# Altium schematic sheet Controller.SchDoc
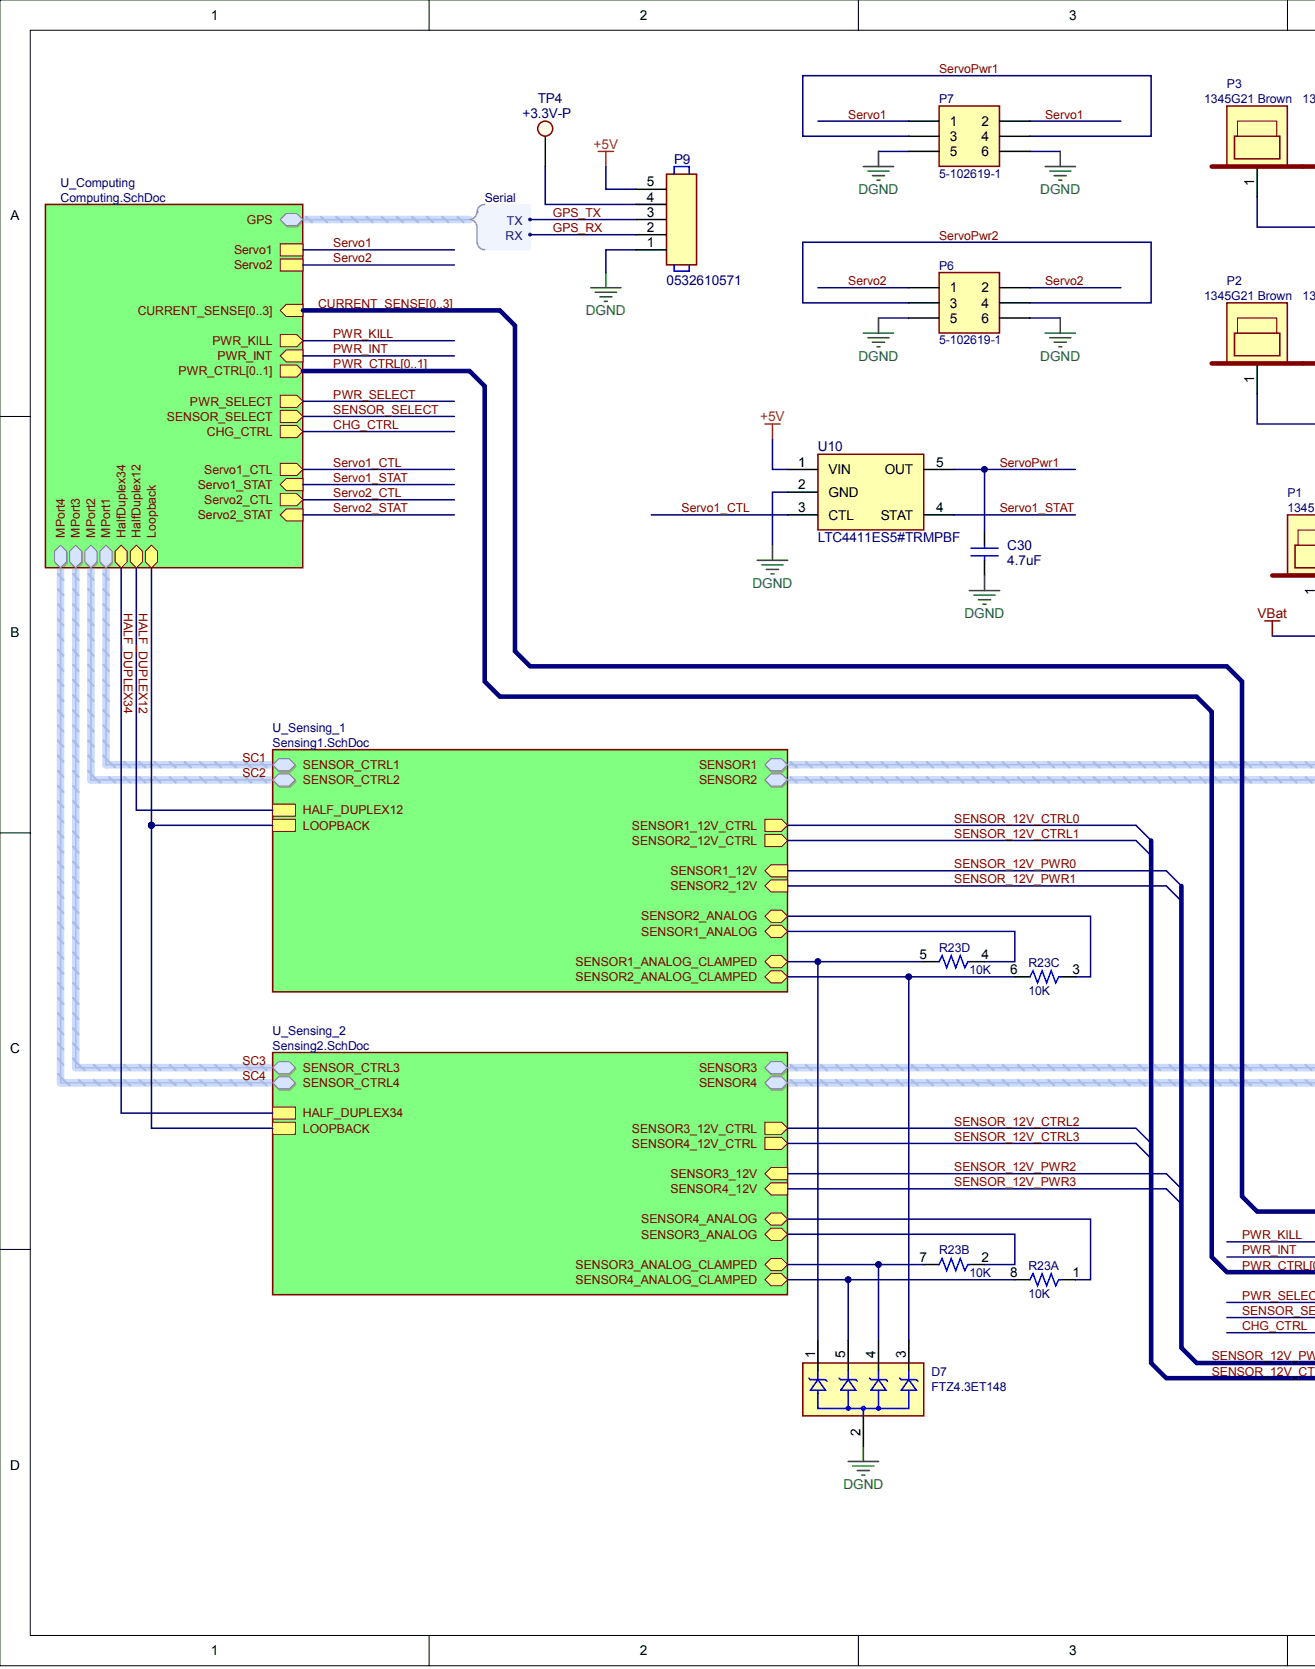
[diagram p0: Controller.SchDoc, left]
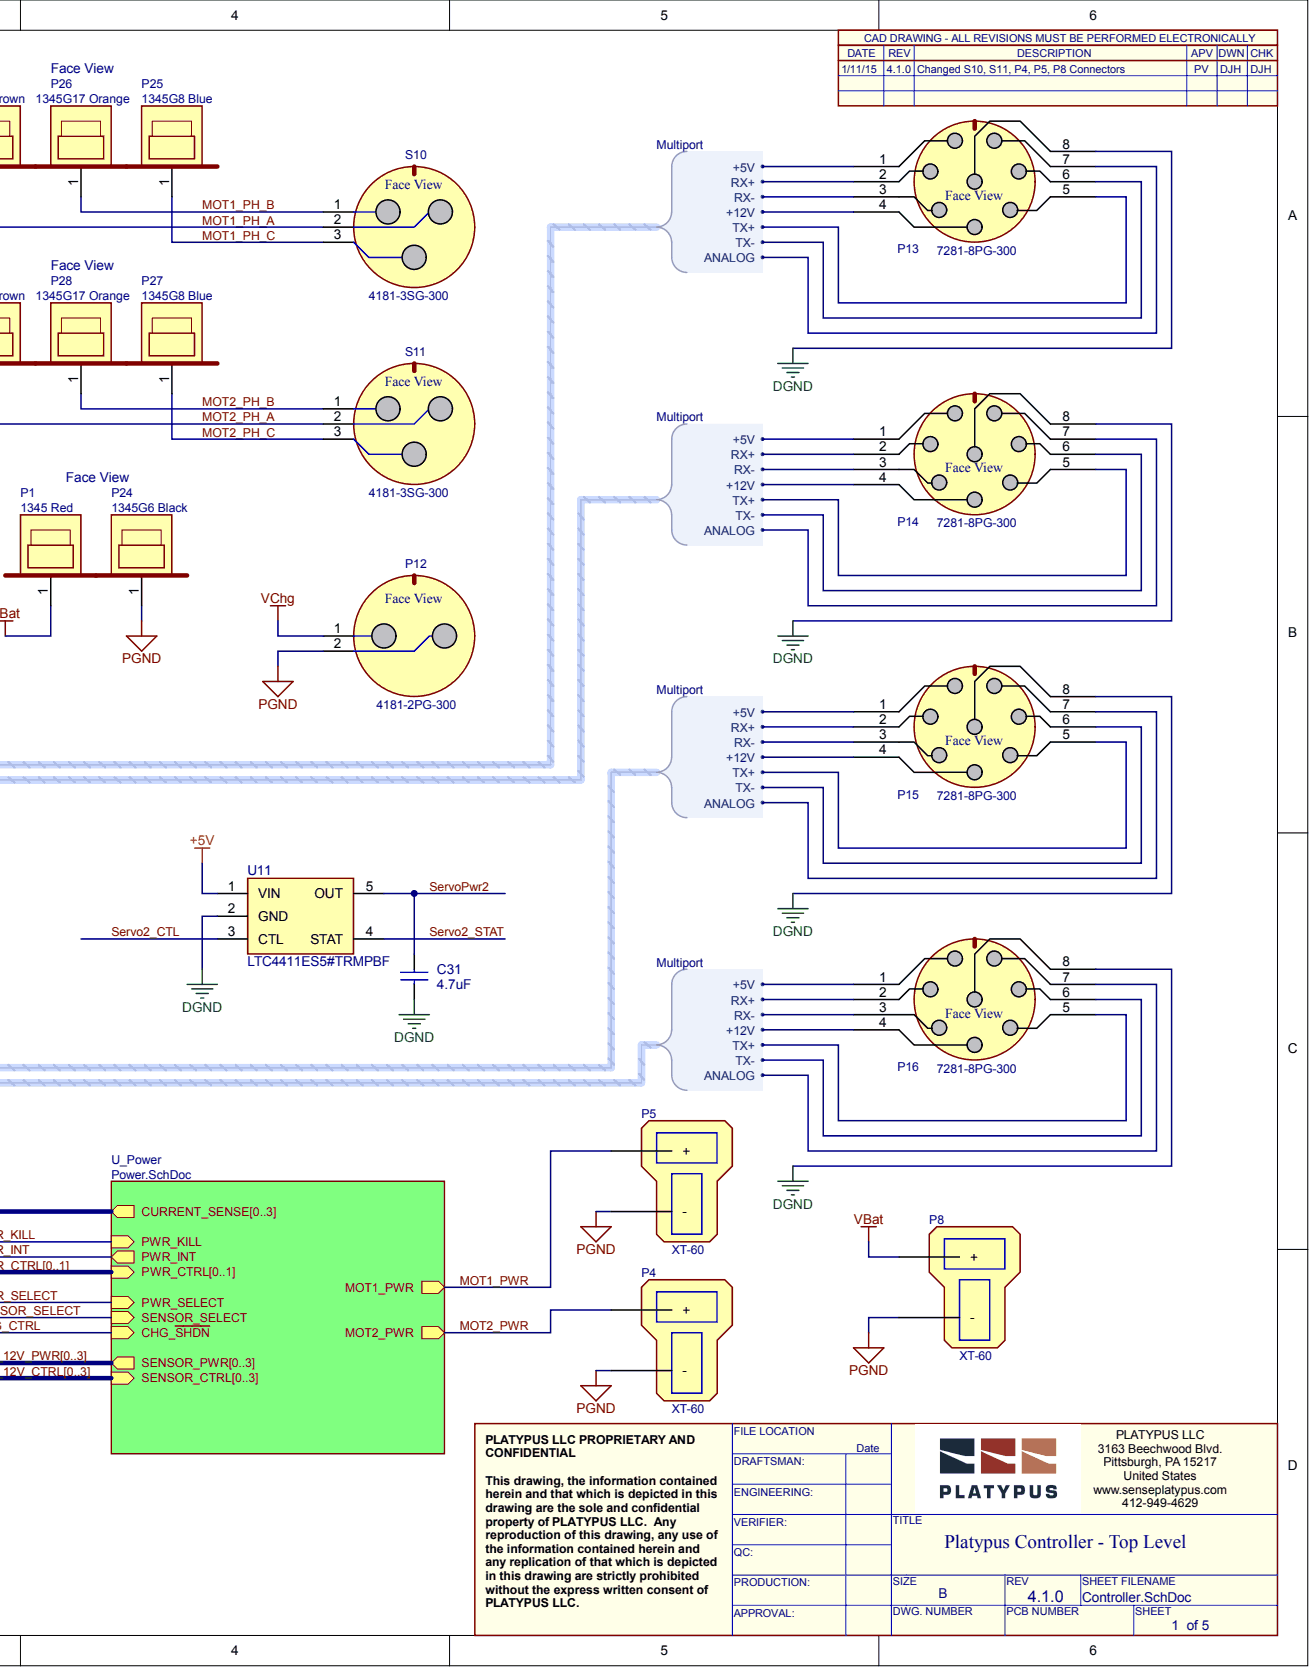
[diagram p1: Controller.SchDoc, right]

## Parts
C30  4.7uF
C31  4.7uF
D7  FTZ4.3ET148
P1  1345 Red  Manufacturer Part Number: 1345
P2  1345G21 Brown  Manufacturer Part Number: 1345G21
P3  1345G21 Brown  Manufacturer Part Number: 1345G21
P4  XT-60
P5  XT-60
P6  5-102619-1
P7  5-102619-1
P8  XT-60
P9  0532610571
P12  4181-2PG-300
P13  7281-8PG-300
P14  7281-8PG-300
P15  7281-8PG-300
P16  7281-8PG-300
P24  1345G6 Black  Manufacturer Part Number: 1345G6
P25  1345G8 Blue  Manufacturer Part Number: 1345G8
P26  1345G17 Orange  Manufacturer Part Number: 1345G17
P27  1345G8 Blue  Manufacturer Part Number: 1345G8
P28  1345G17 Orange  Manufacturer Part Number: 1345G17
R23  10K  (parts A,B,C,D)
S10  4181-3SG-300
S11  4181-3SG-300
TP4  +3.3V-P
U10  LTC4411ES5#TRMPBF
U11  LTC4411ES5#TRMPBF

## Nets
+5V: P9.5 U10.1(VIN) U11.1(VIN)
DGND: C30.2 C31.2 D7.2 P6.5 P6.6 P7.5 P7.6 P9.1 P13.8 P14.8 P15.8 P16.8 U10.2(GND) U11.2(GND)
PGND: P4.1 P5.1 P8.1 P12.2 P24.1
VBAT: P1.1 P8.2
VCHG: P12.1
GPS_RX: P9.2
GPS_TX: P9.3
MOT1_PH_A: P3.1 S10.2
MOT1_PH_B: P26.1 S10.1
MOT1_PH_C: P25.1 S10.3
MOT1_PWR: P5.2
MOT2_PH_A: P2.1 S11.2
MOT2_PH_B: P28.1 S11.1
MOT2_PH_C: P27.1 S11.3
MOT2_PWR: P4.2
SERVO1: P7.1 P7.2
SERVO1_CTL: U10.3(CTL)
SERVO1_STAT: U10.4(STAT)
SERVO2: P6.1 P6.2
SERVO2_CTL: U11.3(CTL)
SERVO2_STAT: U11.4(STAT)
SERVOPWR1: C30.1 P7.3 P7.4 U10.5(OUT)
SERVOPWR2: C31.1 P6.3 P6.4 U11.5(OUT)
NetD7_1: D7.1 R23.5
NetD7_3: D7.3 R23.6
NetD7_4: D7.4 R23.7
NetD7_5: D7.5 R23.8
NetP9_4: P9.4 TP4.1
NetP13_1: P13.1
NetP13_2: P13.2
NetP13_3: P13.3
NetP13_4: P13.4
NetP13_5: P13.5
NetP13_6: P13.6
NetP13_7: P13.7
NetP14_1: P14.1
NetP14_2: P14.2
NetP14_3: P14.3
NetP14_4: P14.4
NetP14_5: P14.5
NetP14_6: P14.6
NetP14_7: P14.7
NetP15_1: P15.1
NetP15_2: P15.2
NetP15_3: P15.3
NetP15_4: P15.4
NetP15_5: P15.5
NetP15_6: P15.6
NetP15_7: P15.7
NetP16_1: P16.1
NetP16_2: P16.2
NetP16_3: P16.3
NetP16_4: P16.4
NetP16_5: P16.5
NetP16_6: P16.6
NetP16_7: P16.7
NetR23_1: R23.1
NetR23_2: R23.2
NetR23_3: R23.3
NetR23_4: R23.4
CHG_CTRL:
CURRENT_SENSE0:  [also labelled CURRENT_SENSE]
CURRENT_SENSE1:  [also labelled CURRENT_SENSE]
CURRENT_SENSE2:  [also labelled CURRENT_SENSE]
CURRENT_SENSE3:  [also labelled CURRENT_SENSE]
HALF_DUPLEX12:
HALF_DUPLEX34:
LOOPBACK:
PWR_CTRL0:  [also labelled PWR_CTRL]
PWR_CTRL1:  [also labelled PWR_CTRL]
PWR_INT:
PWR_KILL:
PWR_SELECT:
SC1.12V_CTRL:
SC1.485/~{232}:
SC1.ANALOG/GPIO5:
SC1.~{RX_EN}:
SC1.RX+/GPIO1:
SC1.RX-/GPIO2:
SC1.TE485:
SC1.TX+/GPIO3:
SC1.TX-/GPIO4:
SC1.TX_EN:
SC2.12V_CTRL:
SC2.485/~{232}:
SC2.ANALOG/GPIO5:
SC2.~{RX_EN}:
SC2.RX+/GPIO1:
SC2.RX-/GPIO2:
SC2.TE485:
SC2.TX+/GPIO3:
SC2.TX-/GPIO4:
SC2.TX_EN:
SC3.12V_CTRL:
SC3.485/~{232}:
SC3.ANALOG/GPIO5:
SC3.~{RX_EN}:
SC3.RX+/GPIO1:
SC3.RX-/GPIO2:
SC3.TE485:
SC3.TX+/GPIO3:
SC3.TX-/GPIO4:
SC3.TX_EN:
SC4.12V_CTRL:
SC4.485/~{232}:
SC4.ANALOG/GPIO5:
SC4.~{RX_EN}:
SC4.RX+/GPIO1:
SC4.RX-/GPIO2:
SC4.TE485:
SC4.TX+/GPIO3:
SC4.TX-/GPIO4:
SC4.TX_EN:
SENSOR_12V_CTRL0:  [also labelled SENSOR_12V_CTRL]
SENSOR_12V_CTRL1:  [also labelled SENSOR_12V_CTRL]
SENSOR_12V_CTRL2:  [also labelled SENSOR_12V_CTRL]
SENSOR_12V_CTRL3:  [also labelled SENSOR_12V_CTRL]
SENSOR_12V_PWR0:  [also labelled SENSOR_12V_PWR]
SENSOR_12V_PWR1:  [also labelled SENSOR_12V_PWR]
SENSOR_12V_PWR2:  [also labelled SENSOR_12V_PWR]
SENSOR_12V_PWR3:  [also labelled SENSOR_12V_PWR]
SENSOR_SELECT:

## Library data of the parts
C30 C31 = (no symbol name): Manufacturer: Murata Electronics North America; Manufacturer Part Number: GRM21BR61C475KA88L; Capacitance: 4.7µF; Voltage - Rated: 16V; Tolerance: ±10%; Temperature Coefficient: X5R; Supplier 1: Digi-Key; Supplier Part Number 1: 490-3338-1-ND; Category: Capacitors; Packaging: Cut Tape (CT); Mounting Type: Surface Mount, MLCC; Operating Temperature: -55°C ~ 85°C; Applications: General Purpose; Ratings: -; Package/Case: 0805 (2012 Metric); Size / Dimension: 0.079" L x 0.049" W (2.00mm x 1.25mm); Height - Seated (Max): -; Thickness (Max): 0.053" (1.35mm); Lead Spacing: -; Features: -; Lead Style: -; ComponentLink1URL: http://www.murata.com/products/catalog/pdf/c02e.pdf; ComponentLink1Description: http://www.murata.com/products/catalog/pdf/c02e.pdf
D7 = (no symbol name): Manufacturer: Rohm Semiconductor; Configuration: 2 Pair Common Anode; Voltage - Zener (Nom) (Vz): 4.3V; Power - Max: 200mW; Impedance (Max) (Zzt): 100 Ohm; Current - Reverse Leakage @ Vr: 5µA @ 1V; Supplier 1: Digi-Key; Supplier Part Number 1: FTZ4.3ET148CT-ND; Manufacturer Part Number: FTZ4.3ET148; RoHS: RoHS Compliant; Category: Discrete Semiconductor Products; Stock 1: 7923; Pricing 1: 1=0.53, 10=0.413, 25=0.3488, 100=0.2841, 250=0.23532, 500=0.19438, 1000=0.1456 (USD); Packaging: Cut Tape (CT); Tolerance: -; Voltage - Forward (Vf) (Max) @ If: -; Operating Temperature: -; Mounting Type: Surface Mount; Package / Case: SC-74A, SOT-753; Supplier Device Package: SMD5; ComponentLink1URL: http://rohmfs.rohm.com/en/products/databook/datasheet/discrete/diode/zener/ftz4.3e.pdf; ComponentLink1Description: http://rohmfs.rohm.com/en/products/databook/datasheet/discrete/diode/zener/ftz4.3e.pdf
P1 P24 P25 P27 = (no symbol name): Manufacturer: Anderson Power Products; Number of Positions: 1; Wire Gauge Range: 14-10; Supplier 1: Mouser; RoHS: RoHS Compliant; Category: Heavy Duty Power Connectors; Contact Plating: Tin; Packaging: Bulk; Series: PP45; Termination Style: Crimp; Brand: Anderson Power Products; Contact Material: Copper; Product Type: Connectors; Standard Pack Qty: 100; Tradename: Powerpole; RoHS - Mouser: Y; Minimum Order Quantity: 1; Reeling: False
  P1: Supplier Part Number 1: 879-1345; Stock 1: 1746; Pricing 1: 1=1.26, 25=1.13, 50=1.03, 100=0.974, 250=0.893, 500=0.832 (USD)
  P24: Supplier Part Number 1: 879-1345G6; Stock 1: 366; Pricing 1: 1=1.36, 25=1.22, 50=1.11, 100=1.05, 250=0.965, 500=0.899 (USD)
  P25 P27: Supplier Part Number 1: 879-1345G8; Stock 1: 60; Pricing 1: 1=1.08, 25=1.07, 50=1.05, 100=0.966, 250=0.900, 500=0.891 (USD)
P2 P3 P26 P28 = (no symbol name): Manufacturer: Anderson Power Products; Current Rating: 45 A; Voltage Rating: 600 V; Wire Gauge Range: 14-10; Supplier 1: Mouser; RoHS: RoHS Compliant; Category: Heavy Duty Power Connectors; Pricing 1: 1=1.35, 25=1.16, 50=1.11, 100=0.893, 250=0.807, 500=0.720 (USD); Housing Material: Polycarbonate (PC); Packaging: Bulk; Series: PP45; Brand: Anderson Power Products; Product Type: Housings; Standard Pack Qty: 100; Tradename: Powerpole; RoHS - Mouser: Y; Minimum Order Quantity: 1; Reeling: False; ComponentLink1URL: http://www.mouser.com/ds/2/22/ANDERSON-PP45-187915.pdf; ComponentLink1Description: http://www.mouser.com/ds/2/22/ANDERSON-PP45-187915.pdf
  P2 P3: Supplier Part Number 1: 879-1345G21; Stock 1: 145
  P26 P28: Supplier Part Number 1: 879-1345G17; Stock 1: 171
P6 P7 = (no symbol name): Manufacturer: TE Connectivity; Connector Type: Header, Shrouded; Number of Positions: 6; Number of Positions Loaded: All; Pitch: 0.100" (2.54mm); Supplier 1: Digi-Key; Supplier Part Number 1: A33113-ND; Manufacturer Part Number: 5-102619-1; Category: Connectors, Interconnects; Packaging: Tray; Contact Type: Male Pin; Number of Rows: 2; Row Spacing: 0.100" (2.54mm); Contact Mating Length: 0.318" (8.08mm); Mounting Type: Through Hole; Termination: Solder; Fastening Type: Detent Lock; Features: -; Contact Finish: Gold; Contact Finish Thickness: 15µin (0.38µm); Color: Black; ComponentLink1URL: http://www.te.com/commerce/DocumentDelivery/DDEController?Action=srchrtrv&DocNm=102619&DocType=Customer+Drawing&DocLang=English; ComponentLink1Description: http://www.te.com/commerce/DocumentDelivery/DDEController?Action=srchrtrv&DocNm=102619&DocType=Customer+Drawing&DocLang=English
P9 = (no symbol name): Manufacturer: Molex Inc; Connector Type: Header, Shrouded; Number of Positions: 5; Number of Positions Loaded: All; Pitch: 0.049" (1.25mm); Supplier 1: Digi-Key; Supplier Part Number 1: WM7623CT-ND; Manufacturer Part Number: 0532610571; RoHS: RoHS Compliant; Category: Connectors, Interconnects; Stock 1: 2124; Pricing 1: 1=1.54, 10=1.395, 25=1.3096, 50=1.2526, 100=1.1957, 250=1.0818, 500=0.99638 (USD); Packaging: Cut Tape (CT); Contact Type: Blade Pin; Number of Rows: 1; Row Spacing: -; Contact Mating Length: -; Mounting Type: Surface Mount, Right Angle; Termination: Solder; Fastening Type: -; Features: Solder Retention; Contact Finish: Tin; Contact Finish Thickness: 39µin (1.00µm); Color: Natural; ComponentLink1URL: http://www.molex.com/pdm_docs/sd/532610571_sd.pdf; ComponentLink1Description: http://www.molex.com/pdm_docs/sd/532610571_sd.pdf
P12 = (no symbol name): Manufacturer: Conxall/Switchcraft; Connector Type: Receptacle, Male Pins; Number of Positions: 2; Ingress Protection: Weathertight; Voltage - Rated: 600V; Supplier 1: Digi-Key; Supplier Part Number 1: 4181-2PG-300-ND; Manufacturer Part Number: 4181-2PG-300; Category: Connectors, Interconnects; Packaging: Bulk; Shell Size - Insert: -; Shell Size, MIL: -; Mounting Type: Panel Mount, Bulkhead - Front Side Nut; Termination: Solder; Fastening Type: Bayonet Lock; Orientation: Keyed; Shell Material, Finish: Nylon; Contact Finish: Gold; Features: -; Contact Finish Thickness: -; Current Rating: -; Operating Temperature: -40°C ~ 115°C; ComponentLink1URL: http://media.digikey.com/pdf/Data%20Sheets/Conxall%20Switchcraft%20PDFs/Multi-con-x.pdf; ComponentLink1Description: http://media.digikey.com/pdf/Data%20Sheets/Conxall%20Switchcraft%20PDFs/Multi-con-x.pdf
P13-P16 = (no symbol name): Manufacturer: Conxall/Switchcraft; Connector Type: Receptacle, Male Pins; Number of Positions: 8; Ingress Protection: Weathertight; Voltage - Rated: 600V; Supplier 1: Digi-Key; Supplier Part Number 1: SC2037-ND; Manufacturer Part Number: 7281-8PG-300; Category: Connectors, Interconnects; Packaging: Bulk; Shell Size - Insert: -; Shell Size, MIL: -; Mounting Type: Panel Mount, Bulkhead - Front Side Nut; Termination: Solder; Fastening Type: Bayonet Lock; Orientation: Keyed; Shell Material, Finish: Nylon; Contact Finish: Gold; Features: -; Contact Finish Thickness: -; Current Rating: -; Operating Temperature: -40°C ~ 115°C; ComponentLink1URL: http://www.switchcraft.com/Documents/Con-X_panel_mount_ex_view.pdf; ComponentLink1Description: http://www.switchcraft.com/Documents/Con-X_panel_mount_ex_view.pdf
R23 = (no symbol name): Manufacturer: Panasonic Electronic Components; Manufacturer Part Number: EXB-V8V103JV; Circuit Type: Isolated; Tolerance: ±5%; Number of Resistors: 4; Power Per Element: 62.5mW; Temperature Coefficient: ±200ppm/°C; Supplier 1: Digi-Key; Supplier Part Number 1: Y4103CT-ND; Category: Resistors; Packaging: Cut Tape (CT); Resistance (Ohms): 10k; Number of Pins: 8; Operating Temperature: -55°C ~ 125°C; Applications: -; Mounting Type: Surface Mount; Package / Case: 1206 (3216 Metric), Concave, Long Side Terminals; Supplier Device Package: 0603; Size / Dimension: 0.126" L x 0.063" W (3.20mm x 1.60mm); Height: 0.028" (0.70mm); ComponentLink1URL: http://industrial.panasonic.com/www-cgi/jvcr13pz.cgi?E+PZ+3+AOC0001+EXBV8V103JV+7+WW; ComponentLink1Description: http://industrial.panasonic.com/www-cgi/jvcr13pz.cgi?E+PZ+3+AOC0001+EXBV8V103JV+7+WW
S10 S11 = (no symbol name): Manufacturer: Conxall/Switchcraft; Connector Type: Receptacle, Female Sockets; Number of Positions: 3; Ingress Protection: Weathertight; Voltage - Rated: 600V; Supplier 1: Digi-Key; Supplier Part Number 1: 4181-3SG-300-ND; Manufacturer Part Number: 4181-3SG-300; RoHS: RoHS Compliant; Category: Connectors, Interconnects; Stock 1: 0; Pricing 1: 25=6.47, 125=5.89 (USD); Packaging: Bulk; Shell Size - Insert: -; Shell Size, MIL: -; Mounting Type: Panel Mount, Bulkhead - Front Side Nut; Termination: Solder; Fastening Type: Bayonet Lock; Orientation: Keyed; Shell Material, Finish: Nylon; Contact Finish: Gold; Features: -; Contact Finish Thickness: -; Current Rating: -; Operating Temperature: -40°C ~ 115°C; ComponentLink1URL: http://media.digikey.com/pdf/Data%20Sheets/Conxall%20Switchcraft%20PDFs/Multi-con-x.pdf; ComponentLink1Description: http://media.digikey.com/pdf/Data%20Sheets/Conxall%20Switchcraft%20PDFs/Multi-con-x.pdf
TP4 = (no symbol name): Manufacturer: Keystone Electronics; Manufacturer Part Number: 5017; Supplier 1: Digi-Key; Supplier Part Number 1: 5017KCT-ND; RoHS: RoHS Compliant; Category: Test and Measurement; Stock 1: 30283; Pricing 1: 1=0.41, 10=0.39, 50=0.2842, 100=0.2731, 250=0.24528, 500=0.23412, 1000=0.1951, 2500=0.17838 (USD); Packaging: Cut Tape (CT); Type: PC Test Point, Miniature; Terminal: 0.010" (0.25mm) Phosphor Bronze, Silver Plated; Mounting Type: Surface Mount; Material: Phosphor Bronze; Color: -; ComponentLink1URL: http://www.keyelco.com/product-pdf.cfm?p=1355; ComponentLink1Description: http://www.keyelco.com/product-pdf.cfm?p=1355
U10 U11 = (no symbol name): Manufacturer: Linear Technology; FET Type: P-Channel; Ratio - Input:Output: 2:1; Internal Switch(s): Yes; Current - Output (Max): 2.6A; Current - Supply: 40µA; Voltage - Supply: 2.6 V ~ 5.5 V; Supplier 1: Digi-Key; Supplier Part Number 1: LTC4411ES5#TRMPBFCT-ND; Manufacturer Part Number: LTC4411ES5#TRMPBF; RoHS: RoHS Compliant; Category: Integrated Circuits (ICs); Stock 1: 3615; Pricing 1: 1=3.88, 25=3.4672, 100=3.1205 (USD); Packaging: Cut Tape (CT); Type: Source Selector Switch; Delay Time - ON: -; Delay Time - OFF: -; Applications: Handheld/Mobile Devices; Operating Temperature: -40°C ~ 85°C; Mounting Type: Surface Mount; Package / Case: SOT-23-5 Thin, TSOT-23-5; Supplier Device Package: TSOT-23-5; ComponentLink1URL: http://www.linear.com/docs/2583; ComponentLink1Description: http://www.linear.com/docs/2583
